# Altium schematic sheet Valve.SchDoc
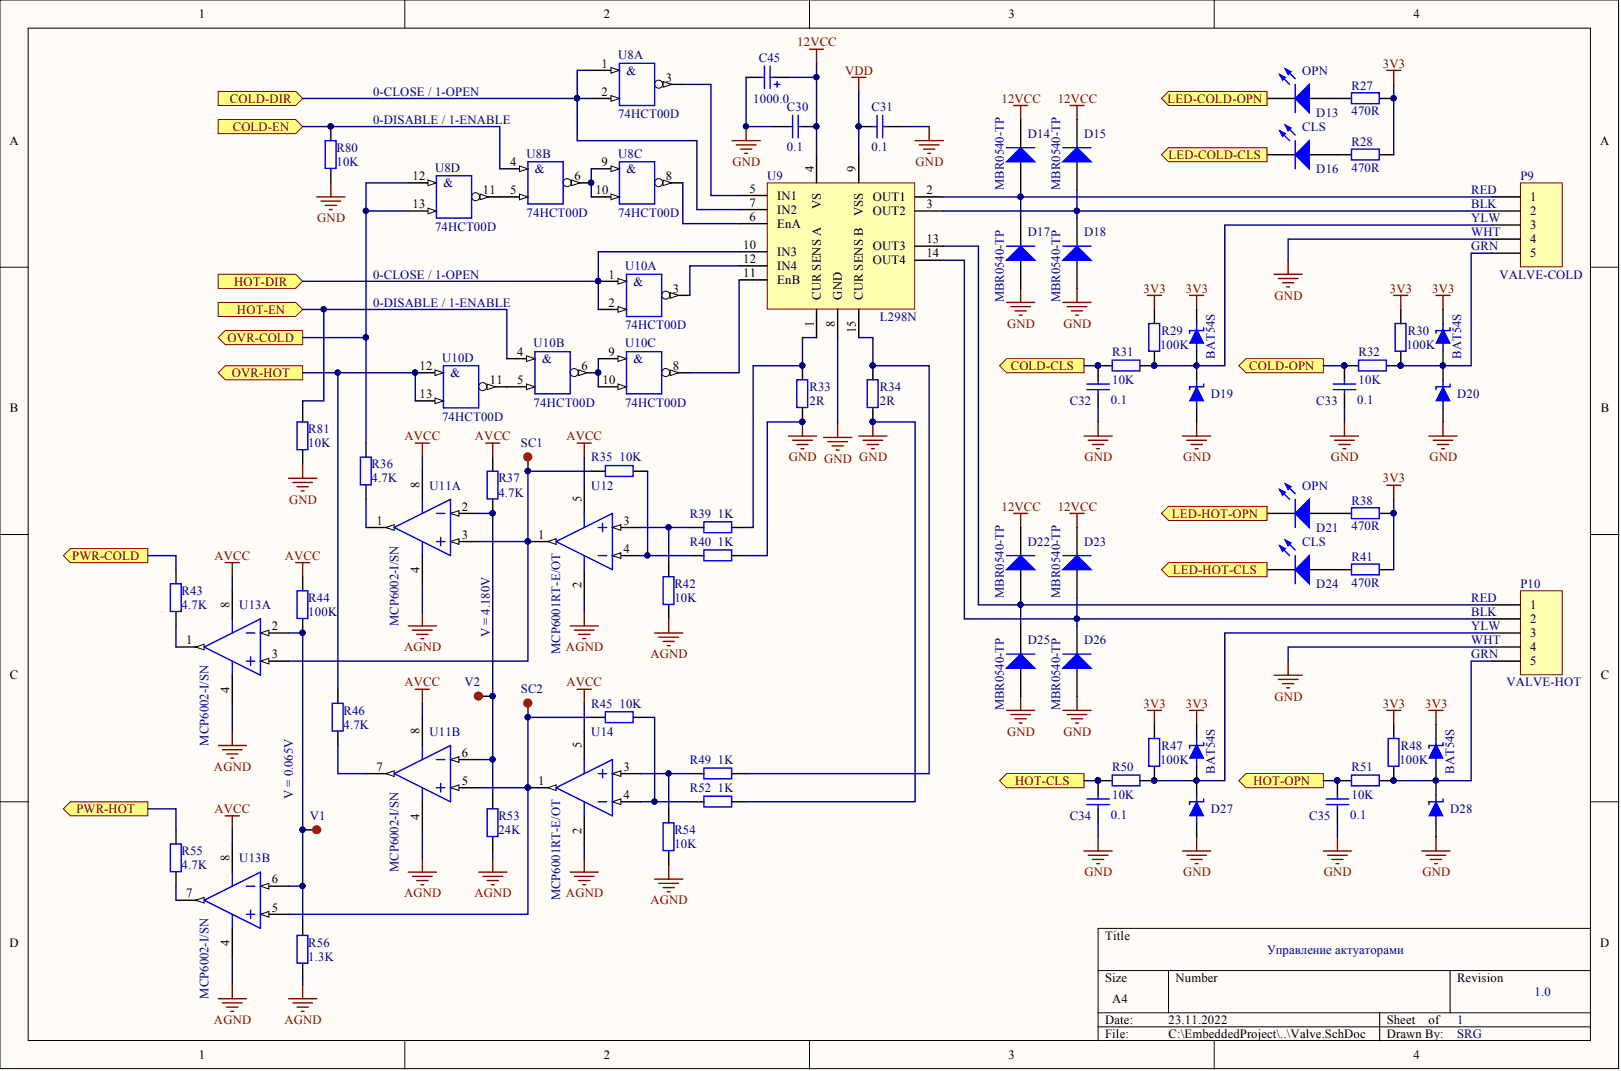
[diagram p0: Valve.SchDoc]

## Parts
C30  value 0.1  [C1206]  (hidden comment: Cap)
C31  value 0.1  [C1206]  (hidden comment: Cap)
C32  value 0.1  [C1206]  (hidden comment: Cap)
C33  value 0.1  [C1206]  (hidden comment: Cap)
C34  value 0.1  [C1206]  (hidden comment: Cap)
C35  value 0.1  [C1206]  (hidden comment: Cap)
C45  value 1000.0  [El-d8-h16.5-F3.81]  (hidden comment: Cap Pol2)
D13  OPN  [LED1206 - RED]
D14  MBR0540-TP  [SOD123]
D15  MBR0540-TP  [SOD123]
D16  CLS  [LED1206 - GREEN]
D17  MBR0540-TP  [SOD123]
D18  MBR0540-TP  [SOD123]
D19  BAT54S  [SOT23]
D20  BAT54S  [SOT23]
D21  OPN  [LED1206 - RED]
D22  MBR0540-TP  [SOD123]
D23  MBR0540-TP  [SOD123]
D24  CLS  [LED1206 - GREEN]
D25  MBR0540-TP  [SOD123]
D26  MBR0540-TP  [SOD123]
D27  BAT54S  [SOT23]
D28  BAT54S  [SOT23]
P9  VALVE-COLD  [KF141V-5]
P10  VALVE-HOT  [KF141V-5]
R27  value 470R  [R1206]  (hidden comment: 520)
R28  value 470R  [R1206]  (hidden comment: 520)
R29  value 100K  [R1206]  (hidden comment: Res2)
R30  value 100K  [R1206]  (hidden comment: Res2)
R31  value 10K  [R1206]  (hidden comment: Res2)
R32  value 10K  [R1206]  (hidden comment: Res2)
R33  value 2R  [R2512-1%]  (hidden comment: Res2)
R34  value 2R  [R2512-1%]  (hidden comment: Res2)
R35  value 10K  [R1206]  (hidden comment: Res2)
R36  value 4.7K  [R1206]  (hidden comment: Res2)
R37  value 4.7K  [R1206]  (hidden comment: Res2)
R38  value 470R  [R1206]  (hidden comment: 520)
R39  value 1K  [R1206]  (hidden comment: Res2)
R40  value 1K  [R1206]  (hidden comment: Res2)
R41  value 470R  [R1206]  (hidden comment: 520)
R42  value 10K  [R1206]  (hidden comment: Res2)
R43  value 4.7K  [R1206]  (hidden comment: Res2)
R44  value 100K  [R1206]  (hidden comment: Res2)
R45  value 10K  [R1206]  (hidden comment: Res2)
R46  value 4.7K  [R1206]  (hidden comment: Res2)
R47  value 100K  [R1206]  (hidden comment: Res2)
R48  value 100K  [R1206]  (hidden comment: Res2)
R49  value 1K  [R1206]  (hidden comment: Res2)
R50  value 10K  [R1206]  (hidden comment: Res2)
R51  value 10K  [R1206]  (hidden comment: Res2)
R52  value 1K  [R1206]  (hidden comment: Res2)
R53  value 24K  [R1206]  (hidden comment: Res2)
R54  value 10K  [R1206]  (hidden comment: Res2)
R55  value 4.7K  [R1206]  (hidden comment: Res2)
R56  value 1.3K  [R1206]  (hidden comment: Res2)
R80  value 10K  [R1206]  (hidden comment: Res2)
R81  value 10K  [R1206]  (hidden comment: Res2)
SC1  Control mark  [Control mark]  (value not drawn)
SC2  Control mark  [Control mark]  (value not drawn)
U8  74HCT00D  [SOIC14_N]  (parts A,B,C,D)
U9  L298N  [Multiwatt15(Vertical)]
U10  74HCT00D  [SOIC14_N]  (parts A,B,C,D)
U11  MCP6002-I/SN  [SOIC-SN8_N]  (parts A,B)
U12  MCP6001RT-E/OT  [SOT-23-OT5_N]
U13  MCP6002-I/SN  [SOIC-SN8_N]  (parts A,B)
U14  MCP6001RT-E/OT  [SOT-23-OT5_N]
V1  Control mark  [Control mark]  (value not drawn)
V2  Control mark  [Control mark]  (value not drawn)

## Ports
COLD-CLS, COLD-DIR, COLD-EN, COLD-OPN, HOT-CLS, HOT-DIR, HOT-EN, HOT-OPN, LED-COLD-CLS, LED-COLD-OPN, LED-HOT-CLS, LED-HOT-OPN, OVR-COLD, OVR-HOT, PWR-COLD, PWR-HOT

## Nets
3V3: D19.2 D20.2 D27.2 D28.2 R27.1 R28.1 R29.1 R30.1 R38.1 R41.1 R47.1 R48.1
12VCC: C30.2 C45.1 D14.2 D15.2 D22.2 D23.2 U9.4(VS)
AGND: R42.1 R53.1 R54.1 R56.1 U11.4 U12.2 U13.4 U14.2
AVCC: R37.2 R44.2 U11.8 U12.5 U13.8 U14.5
GND: C30.1 C31.2 C32.2 C33.2 C34.2 C35.2 C45.2 D17.1 D18.1 D19.1 D20.1 D25.1 D26.1 D27.1 D28.1 P9.4 P10.4 R33.2 R34.2 R40.2 R52.2 R80.2 R81.2 U8.7 U9.8(GND) U10.7
VDD: C31.1 U8.14 U9.9(VSS) U10.14
COLD-CLS: C32.1 R31.2 ; port COLD-CLS
COLD-DIR: U8.1(&) U8.2 U9.7(IN2) ; port COLD-DIR
COLD-EN: R80.1 U8.4(&) ; port COLD-EN
COLD-OPN: C33.1 R32.2 ; port COLD-OPN
HOT-CLS: C34.1 R50.2 ; port HOT-CLS
HOT-DIR: U9.10(IN3) U10.1(&) U10.2 ; port HOT-DIR
HOT-EN: R81.1 U10.4(&) ; port HOT-EN
HOT-OPN: C35.1 R51.2 ; port HOT-OPN
LED-COLD-CLS: D16.2 ; port LED-COLD-CLS
LED-COLD-OPN: D13.2 ; port LED-COLD-OPN
LED-HOT-CLS: D24.2 ; port LED-HOT-CLS
LED-HOT-OPN: D21.2 ; port LED-HOT-OPN
OVR-COLD: R36.2 U8.12(&) U8.13 ; port OVR-COLD
OVR-HOT: R46.2 U10.12(&) U10.13 ; port OVR-HOT
PWR-COLD: R43.2 ; port PWR-COLD
PWR-HOT: R55.2 ; port PWR-HOT
NetD13_1: D13.1 R27.2
NetD14_1: D14.1 D17.2 P9.1 U9.2(OUT1)
NetD15_1: D15.1 D18.2 P9.2 U9.3(OUT2)
NetD16_1: D16.1 R28.2
NetD19_3: D19.3 P9.3 R29.2 R31.1
NetD20_3: D20.3 P9.5 R30.2 R32.1
NetD21_1: D21.1 R38.2
NetD22_1: D22.1 D25.2 P10.1 U9.13(OUT3)
NetD23_1: D23.1 D26.2 P10.2 U9.14(OUT4)
NetD24_1: D24.1 R41.2
NetD27_3: D27.3 P10.3 R47.2 R50.1
NetD28_3: D28.3 P10.5 R48.2 R51.1
NetR33_1: R33.1 R39.2 U9.1
NetR34_1: R34.1 R49.2 U9.15
NetR35_1: R35.1 SC1.1 U11.3 U12.1 U13.3
NetR35_2: R35.2 R40.1 U12.4
NetR36_1: R36.1 U11.1
NetR37_1: R37.1 R53.2 U11.2 U11.6 V2.1
NetR39_1: R39.1 R42.2 U12.3
NetR43_1: R43.1 U13.1
NetR44_1: R44.1 R56.2 U13.2 U13.6 V1.1
NetR45_1: R45.1 SC2.1 U11.5 U13.5 U14.1
NetR45_2: R45.2 R52.1 U14.4
NetR46_1: R46.1 U11.7
NetR49_1: R49.1 R54.2 U14.3
NetR55_1: R55.1 U13.7
NetU8_3: U8.3 U9.5(IN1)
NetU8_5: U8.5 U8.11
NetU8_6: U8.6 U8.9(&) U8.10
NetU8_8: U8.8 U9.6(EnA)
NetU9_11: U9.11(EnB) U10.8
NetU9_12: U9.12(IN4) U10.3
NetU10_5: U10.5 U10.11
NetU10_6: U10.6 U10.9(&) U10.10

## Library data of the parts
C30-C35 = Cap: SMD 1206 Capasitor Body 3.2 x 1.6 mm; Component Kind: Standard; LatestRevisionDate: 17-Jul-2002; LatestRevisionNote: Re-released for DXP Platform.; Library Name: Miscellaneous Devices.IntLib; PackageReference: RAD-0.3; Published: 8-Jun-2000; Signal Integrity: Cap; Simulation: CAP; Publisher: Altium Limited
C45 = Cap Pol2: Polarized Capacitor (Axial); Component Kind: Standard; LatestRevisionDate: 17-Jul-2002; LatestRevisionNote: Re-released for DXP Platform.; Library Name: Miscellaneous Devices.IntLib; PackageDescription: Polarized Capacitor; 2 Leads; PackageReference: POLAR0.8; Published: 8-Jun-2000; Signal Integrity: Cap Pol; Simulation: CAP; Publisher: Altium Limited
D13 D21 = LED1: SMD LED; Value: RED; Component Kind: Standard; LatestRevisionDate: 08-Jul-2005; LatestRevisionNote: Mech Layer 1 removed.; Library Name: Miscellaneous Devices.IntLib; PackageReference: LED-1; Published: 8-Jun-2000; Simulation: LED1; Publisher: Altium Limited
D14 D15 D17 D18 D22 D23 D25 D26 = Diode: Schottky Power Rectifier; Code_JEDEC: DO-214-AB; Component Kind: Standard; LatestRevisionDate: 17-Jul-2002; LatestRevisionNote: Re-released for DXP Platform.; Library Name: Miscellaneous Devices.IntLib; PackageDescription: DO-214-AB/SMC; 2 C-Bend Leads; Body 7.9 x 5.9 mm, inc. leads (LxW); PackageReference: SMC; PackageVersion: Sep-1996; Published: 8-Jun-2000; Signal Integrity: Diode; Simulation: DIODE; Value: MBR0540-TP; Publisher: Altium Limited
D16 D24 = LED1: SMD LED; Value: GREEN; Component Kind: Standard; LatestRevisionDate: 08-Jul-2005; LatestRevisionNote: Mech Layer 1 removed.; Library Name: Miscellaneous Devices.IntLib; PackageReference: LED-1; Published: 8-Jun-2000; Simulation: LED1; Publisher: Altium Limited
D19 D20 D27 D28 = BAT54S: Schottky Barrier Double Diode; Component Kind: Standard; Library Name: Diodes.IntLib; Price: 0.08; Signal Integrity: D; Simulation: BAT54S; Value: BAT54S
P9 P10 = Header 5: Header, 5-Pin; Value: KF141V-5; Component Kind: Standard; LatestRevisionDate: 17-Jul-2002; LatestRevisionNote: Re-released for DXP Platform.; Library Name: Miscellaneous Connectors.IntLib; Signal Integrity: Connector; Publisher: Altium Limited
R27-R32 R38 R41 R47 R48 R50 R51 R80 R81 = Res2: SMD 1206 Resistor Body 3.2 x 1.6 mm; Component Kind: Standard; LatestRevisionDate: 17-Jul-2002; LatestRevisionNote: Re-released for DXP Platform.; Library Name: Miscellaneous Devices.IntLib; PackageReference: AXIAL-0.4; Published: 8-Jun-2000; Signal Integrity: Res; Simulation: RESISTOR; Publisher: Altium Limited
  R31 R32 R50 R51 R80 R81: PackageDescription: Axial Device, Thru-Hole; 2 Leads; 0.4 in Pin Spacing
R33 R34 = Res2: SMD 2515 Resistor; Component Kind: Standard; LatestRevisionDate: 17-Jul-2002; LatestRevisionNote: Re-released for DXP Platform.; Library Name: Miscellaneous Devices.IntLib; PackageReference: AXIAL-0.4; Published: 8-Jun-2000; Signal Integrity: Res; Simulation: RESISTOR; Publisher: Altium Limited
R35-R37 R39 R40 R42-R46 R49 R52-R56 = Res2: SMD 1206 Resistor Body 3.2 x 1.6 mm; PartNumber: RC1206JK-07220RL; DeliveryTime: 7; Component Kind: Standard; Library Name: Miscellaneous Devices.IntLib; Price: 0.90; Signal Integrity: Res; Simulation: RESISTOR
U8 U10 = 74HCT00D: Quad 2-Input NAND Buffered B series Gate, 14-Pin; IC_Technology: C; Component Kind: Standard; ComponentLink1Description: Manufacturer Link; ComponentLink1URL: http://www.fairchildsemi.com/; ComponentLink2Description: Datasheet; ComponentLink2URL: http://www.fairchildsemi.com/ds/CD%2FCD4001BC.pdf; DatasheetVersion: Jan-1999; LatestRevisionDate: 29-May-2009; LatestRevisionNote: Component Body Added.; Library Name: Schlib1.SchLib; PackageDescription: DIP, 14-Pin, Row Spacing 7.62 mm, Pitch 2.54 mm; PackageReference: N14A; PackageVersion: 2000; Published: 17-Jul-2002; Simulation: FSC_4011; Value: 74HCT00D; Publisher: Altium Limited
U9 = L298N: DUAL FULL-BRIDGE DRIVER; Component Kind: Standard; Library Name: Schlib1.SchLib; Value: L298N
U11 U13 = MCP6002-I/SN: 1 MHz, Low-Power Operational Amplifier, 8-Pin SOIC, Industrial Temperature; Manufacturer: Microchip; Code_IPC: SOIC127P600-8; Code_JEDEC: MS-012; Component Kind: Standard; ComponentLink1Description: Manufacturer URL; ComponentLink1URL: http://www.microchip.com/; ComponentLink2Description: Datasheet; ComponentLink2URL: http://ww1.microchip.com/downloads/en/DeviceDoc/21733j.pdf; ComponentLink3Description: Package Specification; ComponentLink3URL: http://www.microchip.com/stellent/groups/techpub_sg/documents/packagingspec/en012702.pdf; DatasheetVersion: revJ, Nov-2009; Library Name: Microchip Linear.IntLib; Mounting Technology: Surface Mount; PackageDescription: 8-Lead Plastic Small Outline (SN) - Narrow, 3.90mm Body [SOIC]; PackageReference: SOIC-SN8; PackageVersion: revBB, Aug-2009; PartNumber: MCP6002-I/SN; Supplier 1: Digi-Key; Supplier 2: Farnell; Supplier 3: Newark; Supplier 4: Mouser; Supplier Part Number 1: MCP6002-I/SN-ND; Supplier Part Number 2: 1292246; Supplier Part Number 3: 63K5672; Supplier Part Number 4: 579-MCP6002-I/SN; Value: MCP6002-I/SN
U12 U14 = MCP6001RT-E/OT: 1 MHz, Low-Power Operational Amplifier, 5-Pin SOT-23, Extended Temperature, Tape and Reel; Manufacturer: Microchip; Code_IPC: SOT23-5; Component Kind: Standard; ComponentLink1Description: Manufacturer URL; ComponentLink1URL: http://www.microchip.com/; ComponentLink2Description: Datasheet; ComponentLink2URL: http://ww1.microchip.com/downloads/en/DeviceDoc/21733j.pdf; ComponentLink3Description: Package Specification; ComponentLink3URL: http://www.microchip.com/stellent/groups/techpub_sg/documents/packagingspec/en012702.pdf; DatasheetVersion: revJ, Nov-2009; Library Name: Microchip Linear.IntLib; Mounting Technology: Surface Mount; PackageDescription: 5-Lead Plastic Small Outline Transistor (OT) [SOT-23]; PackageReference: SOT-23-OT5; PackageVersion: revBB, Aug-2009; PartNumber: MCP6001RT-E/OT; Supplier 1: Digi-Key; Supplier 2: Digi-Key; Supplier 3: Digi-Key; Supplier 4: Farnell; Supplier 5: Farnell; Supplier 6: Newark; Supplier 7: Mouser; Supplier Part Number 1: MCP6001RT-E/OTTR-ND; Supplier Part Number 2: MCP6001RT-E/OTCT-ND; Supplier Part Number 3: MCP6001RT-E/OTDKR-ND; Supplier Part Number 4: 1840894RL; Supplier Part Number 5: 1840894; Supplier Part Number 6: 84R5181; Supplier Part Number 7: 579-MCP6001RT-E/OT; Value: MCP6001RT-E/OT
SC1 SC2 V1 V2 = Control mark: Component Kind: Standard; Library Name: Schlib1.SchLib
